# Altium schematic sheet TopSheet.SchDoc(TopSheet)
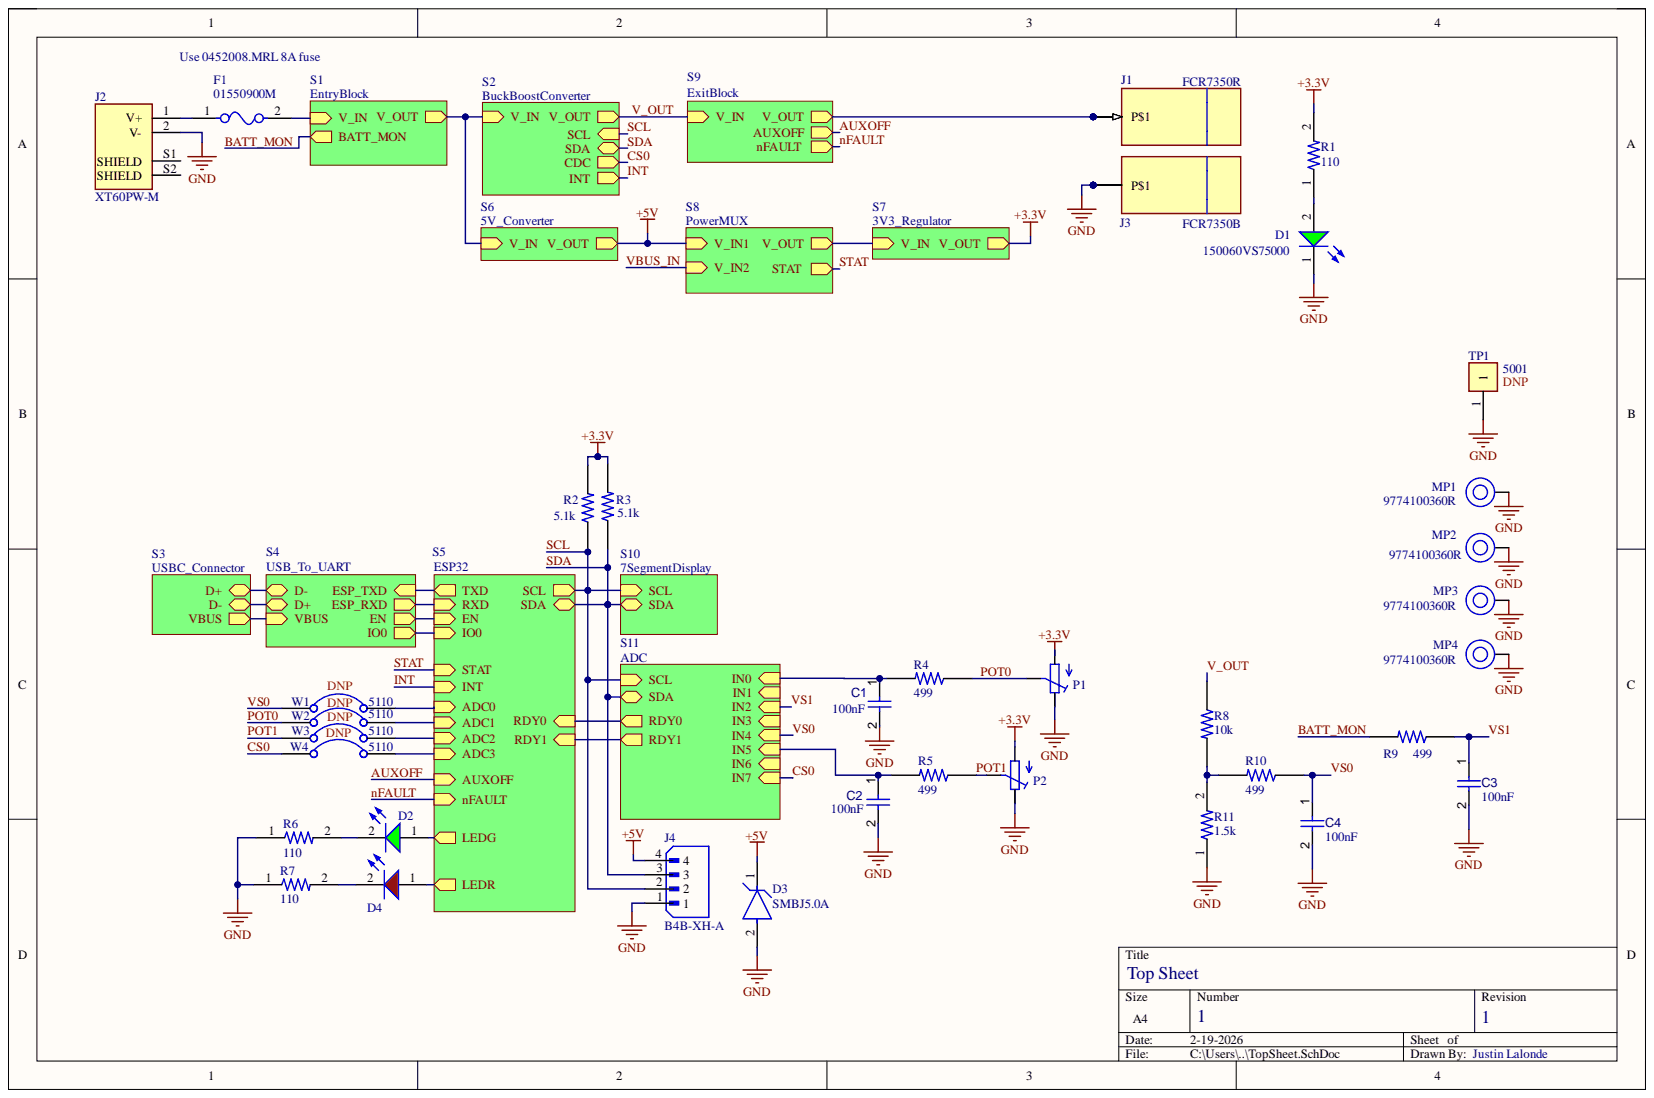
[diagram p0: TopSheet.SchDoc]

## Parts
C1  value 100nF  [Capacitor0402]  (hidden comment: CC0402KRX5R9BB104)
C2  value 100nF  [Capacitor0402]  (hidden comment: CC0402KRX5R9BB104)
C3  value 100nF  [Capacitor0402]  (hidden comment: CC0402KRX5R9BB104)
C4  value 100nF  [Capacitor0402]  (hidden comment: CC0402KRX5R9BB104)
D1  150060VS75000  [WL-SMCW_0603_150060xx75000]
D2  151031VS06000  [WL-TMRC_D3.95H5.3]  (value not drawn)
D3  SMBJ5.0A  [FP-SMBJ-MFG]
D4  151031SS06000  [WL-TMRC_D3.95H5.3]  (value not drawn)
F1  01550900M  [FP-0155-MFG]
J1  FCR7350R  [Copy of FCR7350]
J2  XT60PW-M  [AMASS_XT60PW-M]
J3  FCR7350B  [FCR7350]
J4  B4B-XH-A  [JST_B4B-XH-A]
MP1  9774100360R  [9774100360]
MP2  9774100360R  [9774100360]
MP3  9774100360R  [9774100360]
MP4  9774100360R  [9774100360]
P1  PTV111-4220A-B103  [PTV111-4]  (value not drawn)
P2  PTV111-4220A-B103  [PTV111-4]  (value not drawn)
R1  value 110  [Resistor0603]  (hidden comment: RC0603FR-07110RL)
R2  value 5.1k  [FP-R0402]  (hidden comment: RC0402FR-075K1L)
R3  value 5.1k  [FP-R0402]  (hidden comment: RC0402FR-075K1L)
R4  value 499  [FP-R0402]  (hidden comment: RC0402FR-07499RL)
R5  value 499  [FP-R0402]  (hidden comment: RC0402FR-07499RL)
R6  value 110  [Resistor0603]  (hidden comment: RC0603FR-07110RL)
R7  value 110  [Resistor0603]  (hidden comment: RC0603FR-07110RL)
R8  value 10k  [FP-R0402]  (hidden comment: RC0402FR-0710KL)
R9  value 499  [FP-R0402]  (hidden comment: RC0402FR-07499RL)
R10  value 499  [FP-R0402]  (hidden comment: RC0402FR-07499RL)
R11  value 1.5k  [Resistor0402]  (hidden comment: RC0402FR-071K5L)
TP1  5001  [FP-5001-MFG]
W1  5110  [KEYSTONE_5110]
W2  5110  [KEYSTONE_5110]
W3  5110  [KEYSTONE_5110]
W4  5110  [KEYSTONE_5110]

## Nets
+3.3V: P1.P3 P2.P3 R1.2 R2.2 R3.2
+5V: D3.1 J4.4
GND: C1.2 C2.2 C3.2 C4.2 D1.1 D3.2 J2.2(V-) J3.P$1 J3.P$2 J3.P$3 J3.P$4 J3.P$5 J4.1 MP1.1 MP2.1 MP3.1 MP4.1 P1.P1 P2.P1 R6.1 R7.1 R11.1 TP1.1
BATT_MON: R9.2
CS0: W4.1
POT0: P1.P2 R4.1 W2.1
POT1: P2.P2 R5.1 W3.1
SCL: J4.2 R2.1
SDA: J4.3 R3.1
V_OUT: R8.1
VS0: C4.1 R10.1 W1.1
VS1: C3.1 R9.1
NetC1_1: C1.1 R4.2
NetC2_1: C2.1 R5.2
NetD1_2: D1.2 R1.1
NetD2_1: D2.1
NetD2_2: D2.2 R6.2
NetD4_1: D4.1
NetD4_2: D4.2 R7.2
NetF1_1: F1.1 J2.1(V+)
NetF1_2: F1.2
NetJ1_P$1: J1.P$1 J1.P$2 J1.P$3 J1.P$4 J1.P$5
NetJ2_S1: J2.S1(SHIELD)
NetJ2_S2: J2.S2(SHIELD)
NetR8_2: R8.2 R10.2 R11.2
NetW1_2: W1.2
NetW2_2: W2.2
NetW3_2: W3.2
NetW4_2: W4.2
AUXOFF:
D+:
EN:
ESP_RXD:
ESP_TXD:
IN1:
IN3:
IN6:
INT:
IO0:
nFAULT:
RDY0:
RDY1:
STAT:
V_IN:
VBUS:
VBUS_IN:

## Library data of the parts
C1-C4 = CMP-001-00283-1: 50V 100nF X5R ±10% 0402 Multilayer Ceramic Capacitors MLCC - SMD/SMT ROHS; Case/Package: 0402; Component Kind: Standard; Max Operating Temperature: 85°C; Min Operating Temperature: -55°C; Mounting Technology: Surface Mount; Tolerance: 10%; Voltage Rating: 50V; Library Name: PolyOrbite - Rover - Electrique
D1 = CMP-1426-00006-7: WURTH ELEKTRONIK - 150060VS75000 - LED, Green, SMD, 0603, 30 mA, 2 V, 570 nm; Dominant Wavelength: 570nm; Forward Current: 100mA; Forward Voltage: 2V; Lens Style: Clear, Rectangular; Luminous Intensity: 40mcd; Max Junction Temperature (Tj): 95°C; Max Reverse Voltage (DC): 5V; Peak Wavelength: 572nm; Power Dissipation: 72mW; Test Current: 20mA; Viewing Angle: 140°; Case Code (Imperial): 0603; Case Code (Metric): 1608; Case/Package: 0603; Color: Green; Component Kind: Standard; Height: 700µm; Length: 1.6mm; Library Name: Altium Content Vault; Max Operating Temperature: 85°C; Min Operating Temperature: -40°C; Mount: Surface Mount; Number of Pins: 2; Packaging: Tape and Reel; REACH SVHC: Unknown; Reverse Voltage: 5V; RoHS: Compliant; Wavelength: 570nm; Width: 800µm
D2 = CMP-004-00054-3: WL-TMRC Mono-color Round Color, THT, D3.95 x H5.3mm, Bright Green, 2.2V, 60°; Manufacturer: Wurth Elektronik; Chip Technology: GaAsP/GaP; Dominant Wavelength: 568nm; Luminous Intensity: 30mcd; Peak Wavelength: 560nm; Case/Package: 3mm; Category: Signal LEDs; Component Kind: Standard; Component Type: Standard; ComponentLink1Description: Website Link; ComponentLink1URL: https://www.we-online.com/redexpert/article/151031VS06000?ad; ComponentLink2Description: Datasheet Link; ComponentLink2URL: https://www.we-online.com/redexpert/spec/151031VS06000?ad; Design Item ID: 151031VS06000; Diameter: 3.95mm; Emitting Color: Bright Green; Forward Voltage: 2.2V; Height: 5.3mm; Manufacturer Part Number: 151031VS06000; Match Code: WL-TMRC; Mount: Through Hole; Operating Temperature Max: 85°C; Operating Temperature Min: -40°C; Packaging: Bulk; Pins: 2; Simulation: 3mm_151031VS06000; Source: WL-TMRC.SchLib; Viewing Angle: 60°; Library Name: PolyOrbite - Rover - Electrique
D3 = CMP-004-00072-1: SMBJ Series 5 W 7 V Uni-Directional Surface Mount TVS Diode - SMB; Forward Voltage: 3.5V; Max Surge Current: 65.3A; Case/Package: SMB; Component Kind: Standard; Max Operating Temperature: 150°C; Min Operating Temperature: -65°C; Mounting Technology: Surface Mount; Pins: 2; Library Name: PolyOrbite - Rover - Electrique
D4 = CMP-004-00052-3: WL-TMRC Mono-color Round Color, THT, D3.95 x H5.3mm, Super Red, 2.2V, 60°; Manufacturer: Wurth Elektronik; Chip Technology: AlGaAs; Dominant Wavelength: 640nm; Luminous Intensity: 35mcd; Peak Wavelength: 650nm; Case/Package: 3mm; Category: Signal LEDs; Component Kind: Standard; Component Type: Standard; ComponentLink1Description: Website Link; ComponentLink1URL: https://www.we-online.com/redexpert/article/151031SS06000?ad; ComponentLink2Description: Datasheet Link; ComponentLink2URL: https://www.we-online.com/redexpert/spec/151031SS06000?ad; Design Item ID: 151031SS06000; Diameter: 3.95mm; Emitting Color: Super Red; Forward Voltage: 2.2V; Height: 5.3mm; Manufacturer Part Number: 151031SS06000; Match Code: WL-TMRC; Mount: Through Hole; Operating Temperature Max: 85°C; Operating Temperature Min: -40°C; Packaging: Bulk; Pins: 2; Simulation: 3mm_151031SS06000; Source: WL-TMRC.SchLib; Viewing Angle: 60°; Library Name: PolyOrbite - Rover - Electrique
F1 = CMP-005-00017-1: 01550900M Series - 01550900M Series - SMF OMNI-BLOK® Fuse Block For Nano2® Fuses, Surface Mount, RoHS; Current Rating: 8A; Component Kind: Standard; Mounting Technology: Surface Mount; Library Name: PolyOrbite - Rover - Electrique
J1 = CMP-002-00104-1: Cliff FCR7350R Safety Jack Socket Red Right Angle Pin 4mm 1pc; Current Rating: 24A; Gender: Receptacle; MP: FCR7350B; Voltage Rating: 1kV; Case/Package: None; Check_prices: https://www.snapeda.com/parts/FCR7350B/Cliff+Electronics/view-part/?ref=eda; Color: Red; Component Kind: Standard; Component Type: Standard; Connector Type: Socket; Contact Material: Gold; Contact Plating: Gold; Design Item ID: FCR7350B; Height: 10.922mm; Insulation Material: PVC; Lifecycle Status: Production; MF: Cliff; Mounting Technology: Through Hole; Pins: 5; REACH SVHC: Yes; RoHS: Compliant; SnapEDA_Link: https://www.snapeda.com/parts/FCR7350B/Cliff+Electronics/view-part/?ref=snap; Source: FCR7350B.SchLib; Termination: Through Hole; Voltage Rating (DC): 1kV; Weight: 4.535924g; Width: 11.938mm; Library Name: PolyOrbite - Rover - Electrique
J2 = CMP-002-00093-2: Socket, DC supply, male, PIN: 2; MF: AMASS; Availability: Not in stock; Case/Package: Package; Check_prices: https://www.snapeda.com/parts/XT60PW-M/AMASS/view-part/?ref=eda; Component Kind: Standard; Component Type: Standard; Design Item ID: XT60PW-M; MP: XT60PW-M; Pins: 2; Price: None; SnapEDA_Link: https://www.snapeda.com/parts/XT60PW-M/AMASS/view-part/?ref=snap; Source: XT60PW-M.SchLib; Library Name: PolyOrbite - Rover - Electrique
J3 = CMP-002-00103-2: Banana Jack Connector Standard Banana Solder Black; MF: Cliff; Case/Package: None; Check_prices: https://www.snapeda.com/parts/FCR7350B/Cliff+Electronics/view-part/?ref=eda; Component Kind: Standard; Component Type: Standard; Design Item ID: FCR7350B; MP: FCR7350B; Pins: 5; SnapEDA_Link: https://www.snapeda.com/parts/FCR7350B/Cliff+Electronics/view-part/?ref=snap; Source: FCR7350B.SchLib; Library Name: PolyOrbite - Rover - Electrique
J4 = CMP-002-00100-1: Connector Header Through Hole 4 position 0.098 (2.50mm); MF: JST Sales; Case/Package: None; Check_prices: https://www.snapeda.com/parts/B4B-XH-A/JST/view-part/?ref=eda; Component Kind: Standard; Component Type: Connectors; Design Item ID: B4B-XH-A; MP: B4B-XH-A; Pins: 4; SnapEDA_Link: https://www.snapeda.com/parts/B4B-XH-A/JST/view-part/?ref=snap; Source: B4B-XH-A.SchLib; Library Name: PolyOrbite - Rover - Electrique
MP1-MP4 = CMP-339-000003-3: 9774100360R WA-SMSI SMT Steel Spacer, M3 Internal non Stop; NexarPartId: 39399851; Outside Diameter: 5.9944mm; Plating: Tin; Threaded/Unthreaded: Threaded; Component Kind: Standard; Component Type: Standard; Design Item ID: CMP-03913-39399851-1; Length: 10mm; Material: Steel; Max Operating Temperature: 150°C; Min Operating Temperature: -55°C; Mount: External; Packaging: Tape and Reel; Pin Count: 1; REACH SVHC: Unknown; RoHS: Compliant; Source: PCB1_A25.SCHLIB; Thread Size: M3; Width: 6mm; Library Name: PolyOrbite - Rover - Electrique
P1 P2 = CMP-108-000005-4: Potentiometer, Carbon, 0.05W, 20V, 20% +/-Tol, 1 Turn(s); Actuator Diameter: 5.9944mm; Actuator Length: 19.9898mm; Max Power Dissipation: 50mW; MF: Bourns; MP: PTV111-4220A-B104; Number of Gangs: 1; Value: 10kΩ; Check_prices: https://www.snapeda.com/parts/PTV111-4220A-B104/Bourns/view-part/?ref=eda; Component Kind: Standard; Component Type: Standard; Composition: Carbon; Depth: 16.7mm; Design Item ID: PTV111-4220A-B104; Length: 12mm; Lifecycle Status: Production; Max Operating Temperature: 50°C; Military Standard: Not; Min Operating Temperature: -10°C; Mounting Technology: Brackets, Snap-In, Through Hole; Number of Terminals: 3; Number of Turns: 1; Package: None; Pin Count: 3; Power Dissipation: 50mW; Power Rating: 50mW; Radiation Hardening: No; REACH SVHC: Yes; RoHS: Compliant; Schedule B: 8533400080, 8533400080; SnapEDA_Link: https://www.snapeda.com/parts/PTV111-4220A-B104/Bourns/view-part/?ref=snap; Source: PTV111-4220A-B104.SchLib; Taper: Linear; Termination: PCB; Tolerance: 20%; Type: Potentiometer; Width: 8.5mm; Working Voltage: 20V; Library Name: PolyOrbite - Rover - Electrique
R1 R6 R7 = CMP-009-00335-2: 100mW Thick Film Resistors 75V ±100ppm/℃ ±1% 110Ω 0603 Chip Resistor - Surface Mount ROHS; Case/Package: 0603; Component Kind: Standard; Max Operating Temperature: 155°C; Min Operating Temperature: -55°C; Mounting Technology: Surface Mount; Tolerance: 1%; Voltage Rating: 75V; Library Name: PolyOrbite - Rover - Electrique
R2 R3 = CMP-009-00248-1: 62.5mW Thick Film Resistors 50V ±100ppm/℃ ±1% 5.1kΩ 0402 Chip Resistor - Surface Mount ROHS; Case/Package: 0402; Component Kind: Standard; Library Name: Machine PM; Max Operating Temperature: 155°C; Min Operating Temperature: -55°C; Mounting Technology: Surface Mount; Pins: 2; Tolerance: 1%; Voltage Rating: 50V
R4 R5 R9 R10 = CMP-009-00224-1: Fixed Resistor, Metal Glaze/thick Film, 0.0625W, 499ohm, 50V, 1% +/-Tol, 100ppm/Cel, Surface Mount, 0402; Power: 62.5mW; Case/Package: 0402; Component Kind: Standard; Library Name: Machine PM; Max Operating Temperature: 155°C; Min Operating Temperature: -55°C; Mounting Technology: Surface Mount; Pins: 2; RoHS Compliant: Yes; Tolerance: 1%
R8 = CMP-009-00219-5: Fixed Resistor, Metal Glaze/thick Film, 0.0625W, 10000ohm, 50V, 1% +/-Tol, 100ppm/Cel, Surface Mount, 0402; Power: 62.5mW; Case/Package: 0402; Component Kind: Standard; Library Name: Machine PM; Max Operating Temperature: 155°C; Min Operating Temperature: -55°C; Mounting Technology: Surface Mount; Pins: 2; RoHS Compliant: Yes; Tolerance: 1%
R11 = CMP-009-00337-2: 62.5mW Thick Film Resistors 50V ±100ppm/℃ ±1% 1.5kΩ 0402 Chip Resistor - Surface Mount ROHS; Case/Package: 0402; Component Kind: Standard; Max Operating Temperature: 155°C; Min Operating Temperature: -55°C; Mounting Technology: Surface Mount; Pins: 2; Tolerance: 1%; Voltage Rating: 50V; Library Name: PolyOrbite - Rover - Electrique
TP1 = CMP-162-000001-4: MS27478 - Connectors Receptacle Electrical Solder Mounting Solder Type Hermetic Seal 38999 Series II; Manufacturer: Keystone; Component Kind: Standard; Component Type: Miscellaneous; Design Item ID: CMP-00030-00005-1; Manufacturer Part Number: 5001; Mounting Technology: PCB, Through Hole; Pin Count: 1; Pins: 1; Source: PCB1_A25.SCHLIB; Library Name: PolyOrbite - Rover - Electrique
W1-W4 = CMP-052-000000-2: Jumper Zero Ohm SMT Reel; MF: Keystone Electronics Corp.; Check_prices: https://www.snapeda.com/parts/5110/Keystone/view-part/?ref=eda; Component Kind: Standard; Component Type: Standard; Design Item ID: 5110; Library Name: Machine PM; MP: 5110; Package: None; Pin Count: 2; SnapEDA_Link: https://www.snapeda.com/parts/5110/Keystone/view-part/?ref=snap; Source: 5110.SchLib
